# Altium schematic sheet MCU.SchDoc(MCU)
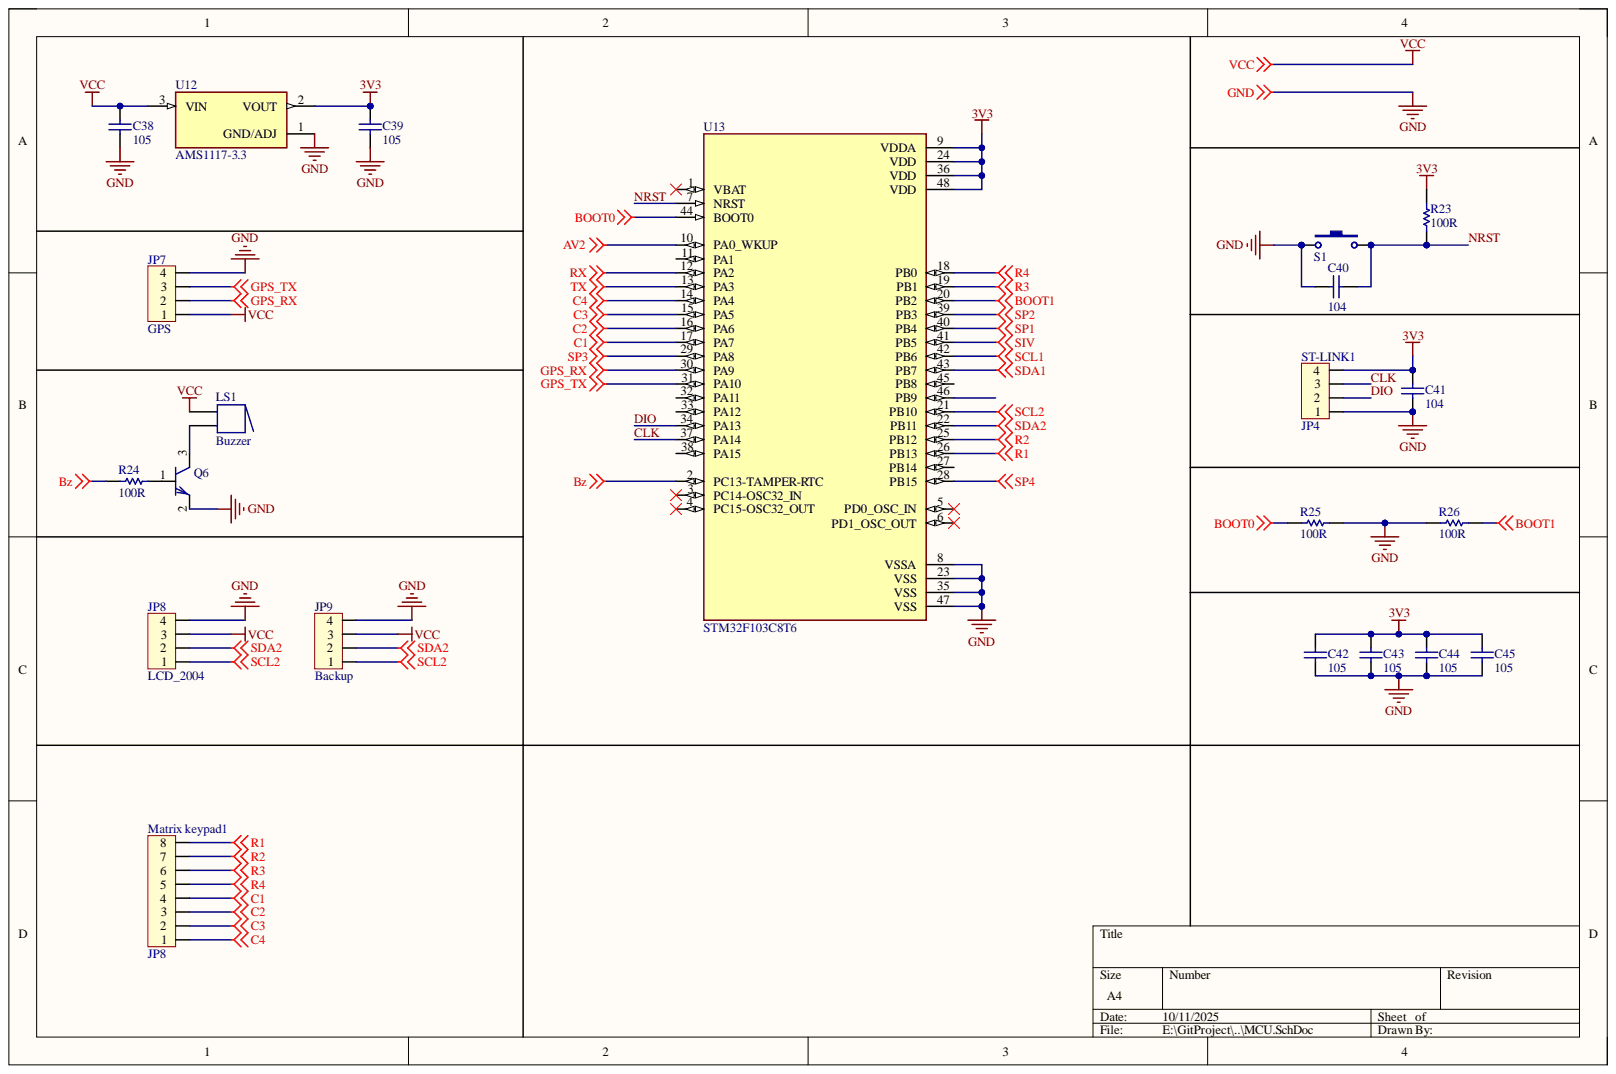
[diagram p0: MCU.SchDoc]

## Parts
C38  value 105  [C-NP-0805]  (hidden comment: Cap Non-pol)
C39  value 105  [C-NP-0805]  (hidden comment: Cap Non-pol)
C40  value 104  [C-NP-0805]  (hidden comment: Cap Non-pol)
C41  value 104  [C-NP-0805]  (hidden comment: Cap Non-pol)
C42  value 105  [C-NP-0805]  (hidden comment: Cap Non-pol)
C43  value 105  [C-NP-0805]  (hidden comment: Cap Non-pol)
C44  value 105  [C-NP-0805]  (hidden comment: Cap Non-pol)
C45  value 105  [C-NP-0805]  (hidden comment: Cap Non-pol)
JP7  GPS  [CON4]
JP8  LCD_2004  [CON4]
JP9  Backup  [CON4]
LS1  Buzzer  [Buzzer]
Matrix_keypad1  JP8  [CON8]
Q6  C1815  [SOT23 [C1815]]  (value not drawn)
R23  value 100R  [R-0805]  (hidden comment: RESISTOR)
R24  value 100R  [R-0805]  (hidden comment: RESISTOR)
R25  value 100R  [R-0805]  (hidden comment: RESISTOR)
R26  value 100R  [R-0805]  (hidden comment: RESISTOR)
S1  SW-SMD  [SW-SMD]  (value not drawn)
ST-LINK1  JP4  [CON4]
U12  AMS1117-3.3  [SOT229P700X180-4N]
U13  STM32F103C8T6  [QFP50P900X900X160-48N]

## Nets
3V3: C39.2 C41.1 C42.2 C43.2 C44.2 C45.2 R23.2 ST-LINK1.4 U12.2 U13.9(VDDA) U13.24(VDD) U13.36(VDD) U13.48(VDD)
AV2: U13.10(PA0_WKUP)
BOOT0: R25.1 U13.44(BOOT0)
BOOT1: R26.2 U13.20(PB2)
Bz: R24.1 U13.2(PC13-TAMPER-RTC)
C1: Matrix_keypad1.4 U13.17(PA7)
C2: Matrix_keypad1.3 U13.16(PA6)
C3: Matrix_keypad1.2 U13.15(PA5)
C4: Matrix_keypad1.1 U13.14(PA4)
GND: C38.1 C39.1 C40.2 C41.2 C42.1 C43.1 C44.1 C45.1 JP7.4 JP8.4 JP9.4 Q6.2 R25.2 R26.1 S1.1 ST-LINK1.1 U12.1 U13.8(VSSA) U13.23(VSS) U13.35(VSS) U13.47(VSS)
GPS_RX: JP7.2 U13.30(PA9)
GPS_TX: JP7.3 U13.31(PA10)
R1: Matrix_keypad1.8 U13.26(PB13)
R2: Matrix_keypad1.7 U13.25(PB12)
R3: Matrix_keypad1.6 U13.19(PB1)
R4: Matrix_keypad1.5 U13.18(PB0)
RX: U13.12(PA2)
SCL1: U13.42(PB6)
SCL2: JP8.1 JP9.1 U13.21(PB10)
SDA1: U13.43(PB7)
SDA2: JP8.2 JP9.2 U13.22(PB11)
SIV: U13.41(PB5)
SP1: U13.40(PB4)
SP2: U13.39(PB3)
SP3: U13.29(PA8)
SP4: U13.28(PB15)
TX: U13.13(PA3)
VCC: C38.2 JP7.1 JP8.3 JP9.3 LS1.1 U12.3
CLK: ST-LINK1.3 U13.37(PA14)
DIO: ST-LINK1.2 U13.34(PA13)
NRST: C40.1 R23.1 S1.2 U13.7(NRST)
NetLS1_2: LS1.2 Q6.3
NetQ6_1: Q6.1 R24.2

Not connected: U13 1(VBAT) 3(PC14-OSC32_IN) 4(PC15-OSC32_OUT) 5(PD0_OSC_IN) 6(PD1_OSC_OUT) 11(PA1) 27(PB14) 32(PA11) 33(PA12) 38(PA15) 45(PB8) 46(PB9)

## Library data of the parts
C38-C45 = Cap Non-pol: Non-polarized Capacitor; Component Kind: Standard; LatestRevisionDate: 17-Jul-2002; LatestRevisionNote: Re-released for DXP Platform.; PackageReference: RAD-0.3; Published: 8-Jun-2000; Library Name: Kiet.IntLib; Publisher: Altium Limited
JP7-JP9 ST-LINK1 = Con4: Connector 4; Component Kind: Standard; Value: Connector 4; Library Name: Kiet.IntLib
LS1 = Buzzer: Magnetic Transducer Buzzer; Component Kind: Standard; ComponentLink1Description: Manufacturer Link; ComponentLink1URL: http://www.megastar.com/linecard/buzzmode/index.php; DatasheetDocument: 17-Dec-2003; LatestRevisionDate: 17-Dec-2003; LatestRevisionNote: Schematic revised, PCB Footprint replaced.; PackageReference: ABSM-1574; Published: 24-Mar-1999; Library Name: Kiet.IntLib; Publisher: Altium Limited
Matrix_keypad1 = Con8: Connector 8; Component Kind: Standard; Value: Connector 8; Library Name: Kiet.IntLib
Q6 = C1815: Q2SC1815; PSpiceTemplate: Q^@REFDES %c %b %e @MODEL; Vendor: Digikey; COMPONENT: 2SC1815; Component Kind: Standard; ComponentLink1Description: Digikey Part Link; ComponentLink2Description: Open Datasheet; Price: 500VND; Published: 13-April-2009; Simulation: Q2SC1815; ComponentLink2URL: H:\LIBRARY\LIBRARY.Datasheet\; Library Name: Kiet.IntLib; Publisher: Hoang Nguyen
S1 = SW-SMD: Switch; Component Kind: Standard; LatestRevisionDate: 17-Jul-2002; LatestRevisionNote: Re-released for DXP Platform.; PackageReference: SPST-2; Published: 24-Mar-1999; Library Name: Kiet.IntLib; Publisher: Altium Limited
U12 = AMS1117-3.3: Sot223/Pkg 1-Amp 3.3-Volt Low Drop Out Voltage Regulatator; MF: Advanced Monolithic Systems; Availability: Not in stock; Check_prices: https://www.snapeda.com/parts/AMS1117-3.3/Advanced+Monolithic+Systems/view-part/?ref=eda; Component Kind: Standard; Library Name: AMS1117-3.3.IntLib; MP: AMS1117-3.3; Package: SOT-223 Seeed Technology; Price: None; SnapEDA_Link: https://www.snapeda.com/parts/AMS1117-3.3/Advanced+Monolithic+Systems/view-part/?ref=snap
U13 = STM32F103C8T6: ARM® Cortex®-M3 STM32F1 Microcontroller IC 32-Bit 72MHz 64KB (64K x 8) FLASH 48-LQFP (7x7); MF: STMicroelectronics; Check_prices: https://www.snapeda.com/parts/STM32F103C8T6/STMicroelectronics/view-part/?ref=eda; Component Kind: Standard; Library Name: STM32F103C8T6.IntLib; MP: STM32F103C8T6; Package: LQFP-48 STMicroelectronics; SnapEDA_Link: https://www.snapeda.com/parts/STM32F103C8T6/STMicroelectronics/view-part/?ref=snap
R23-R26 = RESISTOR: Component Kind: Standard; Description: Resistor; LatestRevisionDate: 17-Jul-2002; LatestRevisionNote: Re-released for DXP Platform.; PackageReference: AXIAL-0.3; Published: 8-Jun-2000; Library Name: Kiet.IntLib; Publisher: Altium Limited
